# Altium schematic sheet Power_Supply.SchDoc(Power_Supply)
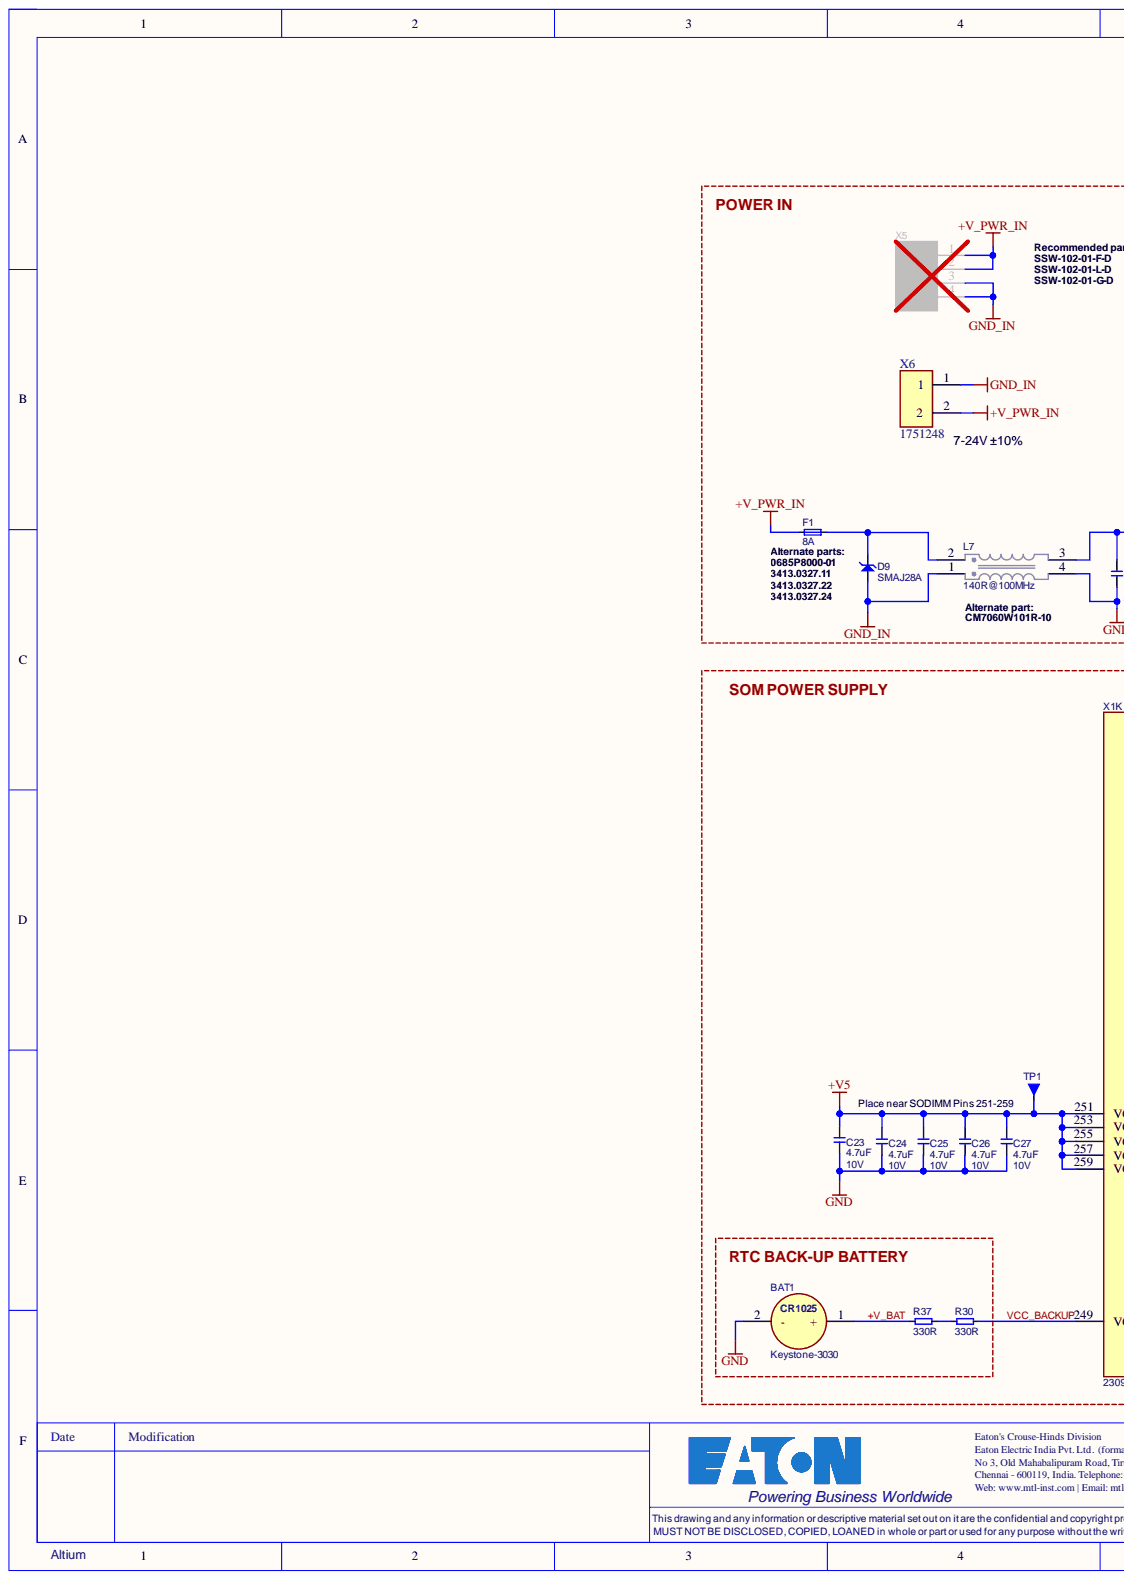
[diagram p0: Power_Supply.SchDoc, left]
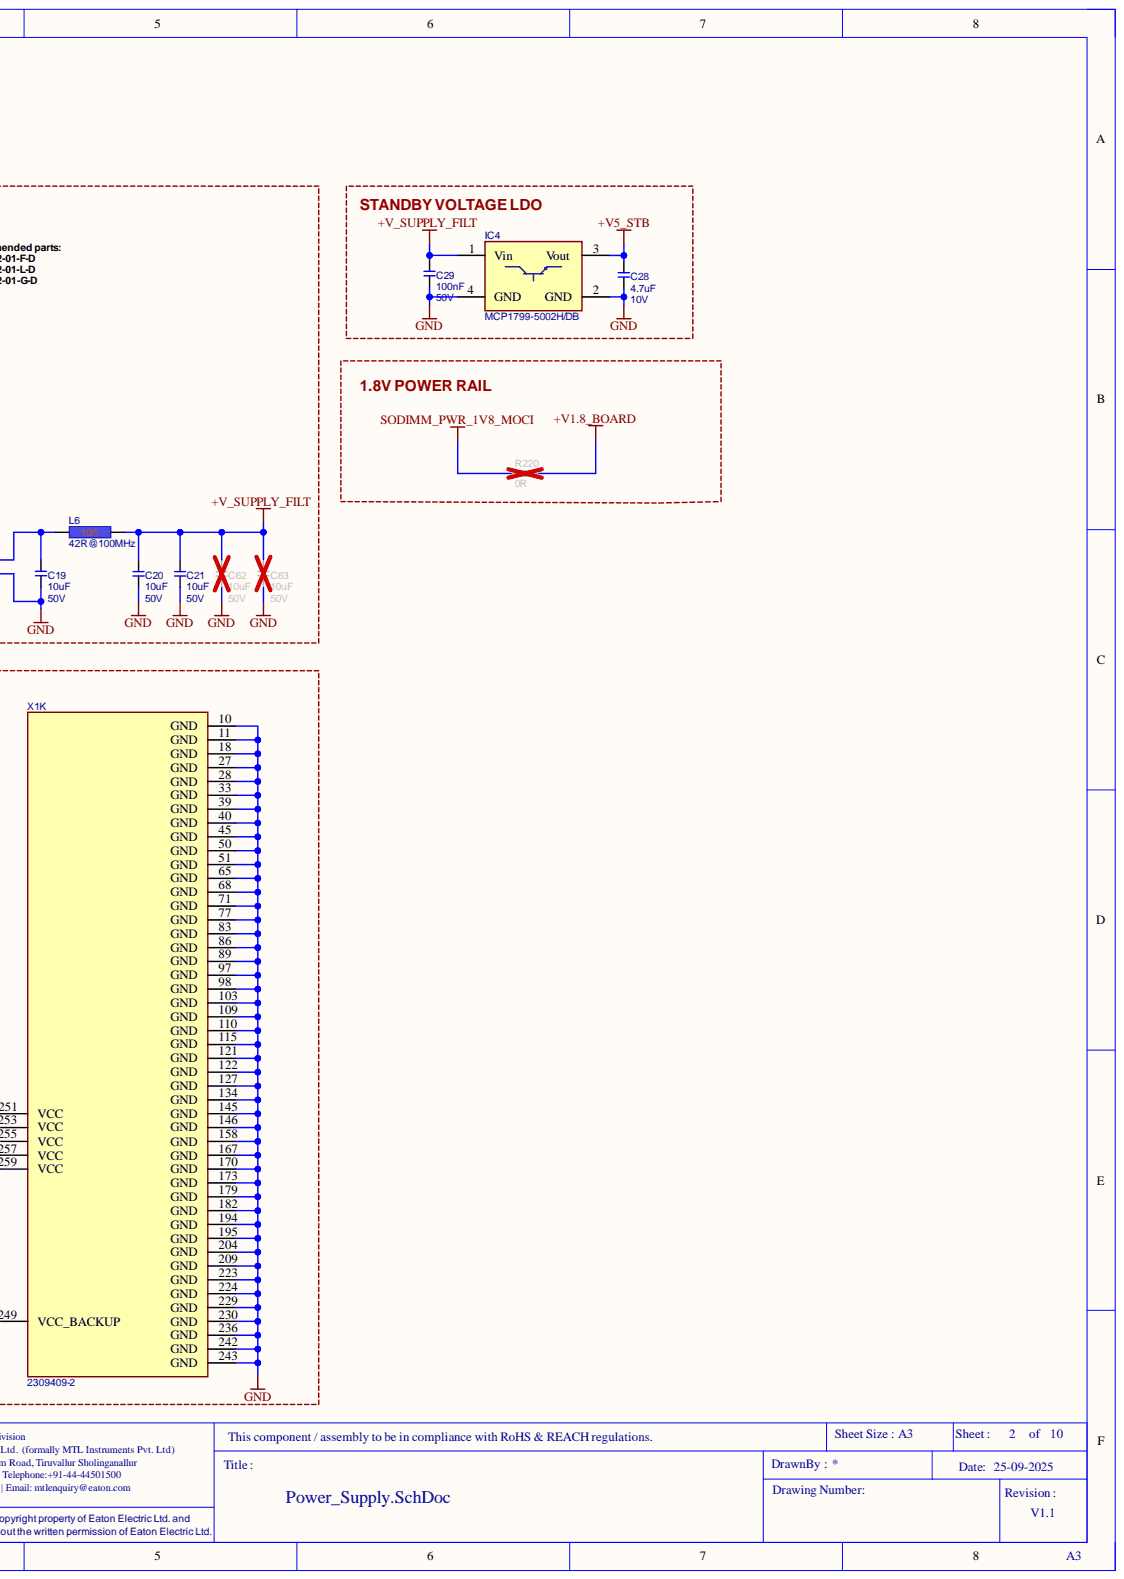
[diagram p1: Power_Supply.SchDoc, right]

## Parts
BAT1  Keystone-3030  [T_Keystone_3030]
C19  10uF  Rating: 50V  [T_CAPC1206X60N]
C20  10uF  Rating: 50V  [T_CAPC1206X60N]
C21  10uF  Rating: 50V  [T_CAPC1206X60N]
C23  4.7uF  Rating: 10V  [T_CAPC0603X33N]
C24  4.7uF  Rating: 10V  [T_CAPC0603X33N]
C25  4.7uF  Rating: 10V  [T_CAPC0603X33N]
C26  4.7uF  Rating: 10V  [T_CAPC0603X33N]
C27  4.7uF  Rating: 10V  [T_CAPC0603X33N]
C28  4.7uF  Rating: 10V  [T_CAPC0603X33N]
C29  100nF  Rating: 50V  [T_CAPC0603X33N]
C62  Rating: 50V  [T_CAPC1206X60N]  (hidden comment: 10uF)
C63  Rating: 50V  [T_CAPC1206X60N]  (hidden comment: 10uF)
D9  Manufacturer Part No.: SMAJ28A  [D-SMAJ28A]  (hidden comment: D-Good-Ark-SMAJ28A)
F1  8A  [T_BelFuse-0685T8000-01]
IC4  MCP1799-5002H/DB  [SOT-223-3]
L6  42R@100MHz  Rating: 10A  [T_Laird_35F0121-0SR-10]
L7  140R@100MHz  [T_Pulse_PA4339]
R30  330R  [RESC1005X40N]
R37  330R  [RESC1005X40N]
R220  0R  [RESC1005X40N]
TP1  Testpin-THT  [T_TP-THT]  (value not drawn)  (no BOM)
X1  2309409-2  [CON-TE-2309409-2]  (part K)
X5  CON-Header-2x2-Female-2.54mm  [CON-Header-2x2-Female-2.54mm]  (value not drawn)
X6  Fixed Terminal Blocks 2P 3.5mm 0DEG  [1751248]  (value not drawn)

## Nets
+V1.8_BOARD: R220.2
+V5: C23.2 C24.2 C25.2 C26.2 C27.2 TP1.1 X1.251(VCC) X1.253(VCC) X1.255(VCC) X1.257(VCC) X1.259(VCC)
+V5_STB: C28.2 IC4.3(Vout)
+V_PWR_IN: F1.1 X6.2
+V_SUPPLY_FILT: C20.2 C21.2 C29.2 C62.2 C63.2 IC4.1(Vin) L6.2
GND: BAT1.2 C19.1 C20.1 C21.1 C23.1 C24.1 C25.1 C26.1 C27.1 C28.1 C29.1 C62.1 C63.1 IC4.2(GND) IC4.4(GND) L7.4 X1.10(GND) X1.11(GND) X1.18(GND) X1.27(GND) X1.28(GND) X1.33(GND) X1.39(GND) X1.40(GND) X1.45(GND) X1.50(GND) X1.51(GND) X1.65(GND) X1.68(GND) X1.71(GND) X1.77(GND) X1.83(GND) X1.86(GND) X1.89(GND) X1.97(GND) X1.98(GND) X1.103(GND) X1.109(GND) X1.110(GND) X1.115(GND) X1.121(GND) X1.122(GND) X1.127(GND) X1.134(GND) X1.145(GND) X1.146(GND) X1.158(GND) X1.167(GND) X1.170(GND) X1.173(GND) X1.179(GND) X1.182(GND) X1.194(GND) X1.195(GND) X1.204(GND) X1.209(GND) X1.223(GND) X1.224(GND) X1.229(GND) X1.230(GND) X1.236(GND) X1.242(GND) X1.243(GND)
GND_IN: D9.A L7.1 X5.3 X5.4 X6.1
SODIMM_PWR_1V8_MOCI: R220.1
+V_BAT: BAT1.1 R37.1
VCC_BACKUP: R30.2 X1.249(VCC_BACKUP)
NetC19_2: C19.2 L6.1 L7.3
NetD9_C: D9.C F1.2 L7.2
NetR30_1: R30.1 R37.2

Not connected: X5 1 2

## Library data of the parts
BAT1 = BAT-Keystone-3030: CR1025 Battery Holder; Manufacturer Part No.: 3030TR; Component Kind: Standard; Manufacturer Name: Keystone; Library Name: Mallow.SchLib
C19-C21 C62 C63 = C-X5R-10uF-50V-20%-1206: Capacitor Ceramic X5R 10uF 50V 20% 1206; Manufacturer Name: Taiyo Yuden; Manufacturer Part No.: UMK316BBJ106ML-T; Component Kind: Standard; Library Name: SoM_Camera_Encoder.SCHLIB; Tolerance: 20%; Type: X5R; Value: 10uF
C23-C28 = C-X5R-4.7uF-10V-10%-0603: Capacitor Ceramic X5R 4.7uF 10V 10% 0603; Manufacturer Name: Samsung Electro-Mechanics; Manufacturer Part No.: CL10A475KP8NNNC; Component Kind: Standard; Library Name: SoM_Camera_Encoder.SCHLIB; Tolerance: 10%; Type: X5R; Value: 4.7uF
C29 = C-X7R-100nF-50V-10%-0603: Capacitor Ceramic X7R 100nF 50V 10% 0603; Manufacturer Name: KEMET; Manufacturer Part No.: C0603C104K5RACTU; Component Kind: Standard; Library Name: SoM_Camera_Encoder.SCHLIB; Tolerance: 10%; Type: X7R; Value: 100nF
D9 = D-Good-Ark-SMAJ28A: Unidirectional ESD protection; Manufacturer Name: Good-Ark Semiconductor; Component Kind: Standard; Library Name: Mallow.SchLib
F1 = F-BelFuse-0685T8000-01: Fast Fuse, Rated 8A, 63V(DC), 1206 (3216 Metric); Component Kind: Standard; Manufacturer Name: Bel Fuse; Manufacturer Part No.: 0685T8000-01; Value: 8A; Library Name: Mallow.SchLib
IC4 = IC-Microchip-MCP1799-5002H/DB: 5V Linear Voltage Regulator, Positive, Fixed, 1 Output, 80mA, SOT-223-3; Component Kind: Standard; Manufacturer Name: Microchip; Manufacturer Part No.: MCP1799-5002H/DB; Library Name: Mallow.SchLib
L6 = L-Laird-35F0121-0SR-10-10A-25%: Power Supply FB 10A; Manufacturer Name: Laird; Tolerance: 25%; Component Kind: Standard; Manufacturer Part No.: 35F0121-0SR-10; Value: 42R@100MHz; Library Name: Mallow.SchLib
L7 = L-Pulse-PA4339.101NLT-140R@100MHz-9A: Common Mode Choke 140 Ohms @ 100 MHz 9A DCR 10mOhm; Manufacturer Name: Pulse Electronics; Component Kind: Standard; Manufacturer Part No.: PA4339.101NLT; Rating: 9A; Value: 140R@100MHz; Library Name: Mallow.SchLib
R30 R37 = R-330R-63mW-5%-0402: Resistor 330 ohm 63mW 5% 0402; Manufacturer Name: Stackpole Electronics Inc; Manufacturer Part No.: RMCF0402JT330R; Component Kind: Standard; Library Name: SoM_Camera_Encoder.SCHLIB; Rating: 63mW; Tolerance: 5%; Value: 330R
R220 = R-0R-63mW-1%-0402: Resistor 0 ohm 63mW 1% 0402; Manufacturer Name: Thin Film Technology Corp.; Manufacturer Part No.: TFAS0402WJUMPF-T10; Component Kind: Standard; Library Name: SoM_Camera_Encoder.SCHLIB; Rating: 63mW; Tolerance: 1%; Value: 0R
TP1 = Testpin-THT: Testpin THT; Library Name: Mallow.SchLib
X1 = CON-TE-2309409-2: DDR4 SODIMM Socket, 260 pins, 5.2mm(H), 0.5mm Pitch; Manufacturer Name: TE Connectivity; Component Kind: Standard; Manufacturer Part No.: 2309409-2; Package: DDR4-SODIMM-260; Temperature Range: -40C to +125C; Library Name: Mallow.SchLib
X5 = CON-Header-2x2-Female-2.54mm: Receptacle Female Socket, 2x2 Pins, 2.54mm pitch; Component Kind: Standard; No. of ways: 2x2; Pitch: 2.54mm; Library Name: Mallow.SchLib
X6 = 1751248: Manufacturer: Phoenix Contact; Arrow Part Number: 1751248; Arrow Price/Stock: https://www.arrow.com/en/products/1751248/phoenix-contact?utm_currency=USD&region=nac; Component Kind: Standard; Datasheet Link: https://datasheet.datasheetarchive.com/originals/distributors/SFDatasheet-2/sf-00051377.pdf; Description: Fixed Terminal Blocks 2P 3.5mm 0DEG; Height: 8.65mm; Mouser Part Number: 651-1751248; Mouser Price/Stock: https://www.mouser.co.uk/ProductDetail/Phoenix-Contact/1751248?qs=bOnNDoERoR01kuhsqWf5xQ%3D%3D; MPN: 1751248; Library Name: Mallow.SchLib
